# Altium schematic sheet Main.SchDoc
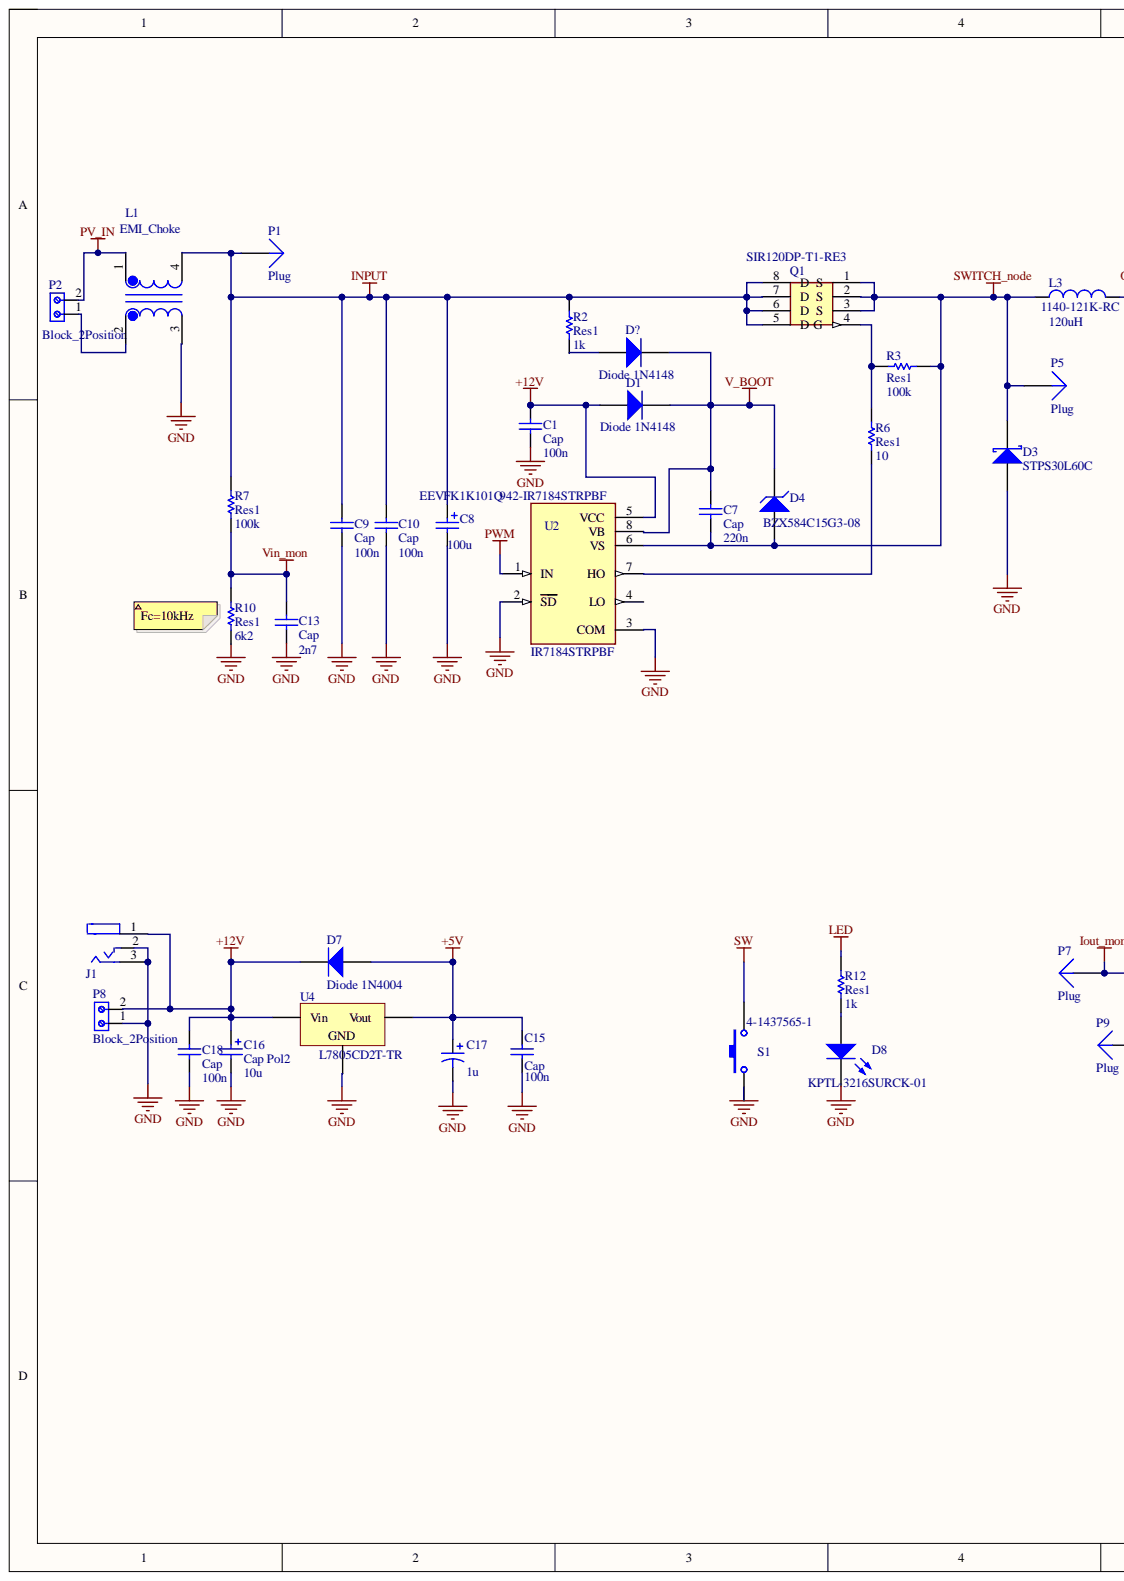
[diagram p0: Main.SchDoc, left]
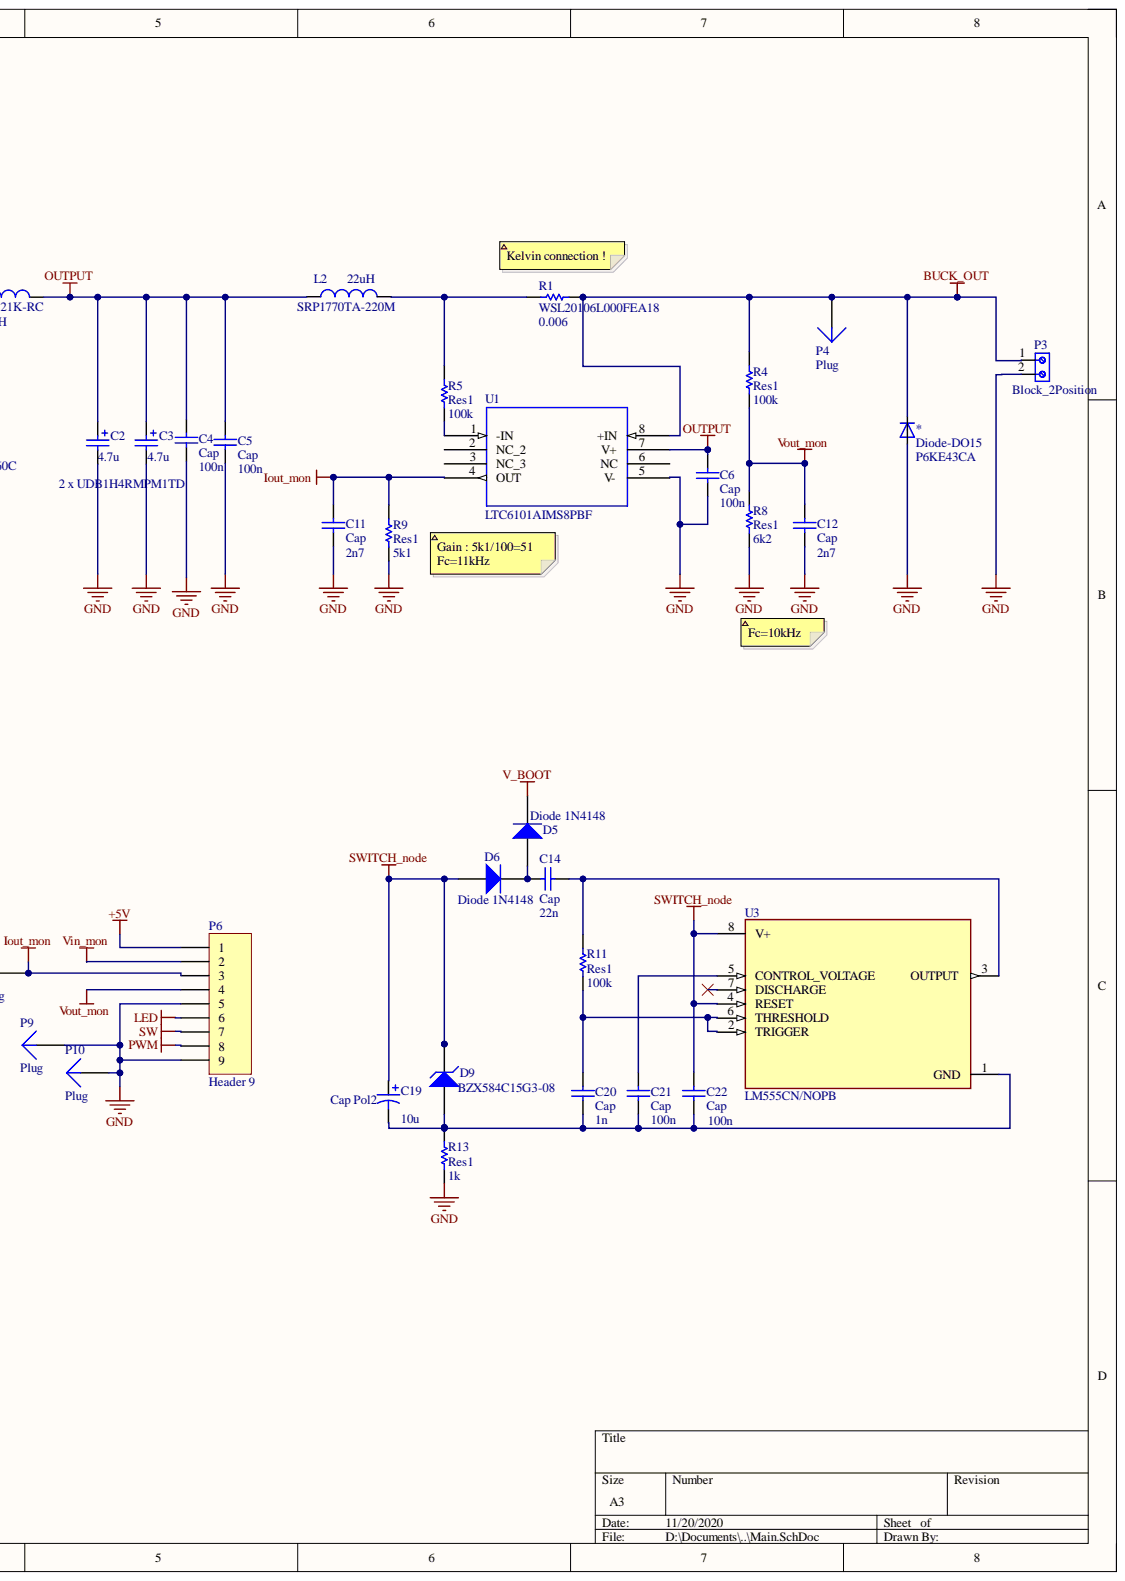
[diagram p1: Main.SchDoc, right]

## Parts
*  Diode-DO15  [Diode-DO15]
C1  Cap  value 100n  [C0805]
C2  2 x UDB1H4RMPM1TD  value 4.7u  [C1-C2]
C3  2 x UDB1H4RMPM1TD  value 4.7u  [C1-C2]
C4  Cap  value 100n  [C0805]
C5  Cap  value 100n  [C0805]
C6  Cap  value 100n  [C0805]
C7  Cap  value 220n  [C0805]
C8  EEVFK1K101Q  value 100u  [C_Pol_EEV-FK1K101Q]
C9  Cap  value 100n  [C0805]
C10  Cap  value 100n  [C0805]
C11  Cap  value 2n7  [C0805]
C12  Cap  value 2n7  [C0805]
C13  Cap  value 2n7  [C0805]
C14  Cap  value 22n  [C0805]
C15  Cap  value 100n  [C0805]
C16  Cap Pol2  value 10u  [C_ECA2CHG100]
C17  1u  [C0805]
C18  Cap  value 100n  [C0805]
C19  Cap Pol2  value 10u  [C_ECA2CHG100]
C20  Cap  value 1n  [C0805]
C21  Cap  value 100n  [C0805]
C22  Cap  value 100n  [C0805]
D1  Diode 1N4148  [diode_1N4004]
D3  STPS30L60C  [Diode_Scho]
D4  BZX584C15G3-08  [diode_zener]
D5  Diode 1N4148  [diode_1N4004]
D6  Diode 1N4148  [diode_1N4004]
D7  Diode 1N4004  [diode_1N4004]
D8  KPTL-3216SURCK-01  [LED]
D9  BZX584C15G3-08  [diode_zener]
D?  Diode 1N4148  [diode_1N4004]
J1  PJ-006A  [CUI_Inc_PJ-006A_MFG]  (value not drawn)
L1  EMI_Choke  [EMI_Choke]
L2  SRP1770TA-220M  value 22uH  [SRP1770TA-220M]
L3  1140-121K-RC  value 120uH  [L_inductor]
P1  Plug  [PIN1]
P2  Block_2Position  [Block_2Position]
P3  Block_2Position  [Block_2Position]
P4  Plug  [PIN1]
P5  Plug  [PIN1]
P6  Header 9  [HDR1X9]
P7  Plug  [PIN1]
P8  Block_2Position  [Block_2Position]
P9  Plug  [PIN1]
P10  Plug  [PIN1]
Q1  SIR120DP-T1-RE3  [MOFET_SIR120DP-T1-RE3]
R1  WSL20106L000FEA18  value 0.006  [R0.006]
R2  Res1  value 1k  [R0805]
R3  Res1  value 100k  [R0805]
R4  Res1  value 100k  [R0805]
R5  Res1  value 100k  [R0805]
R6  Res1  value 10  [R0805]
R7  Res1  value 100k  [R0805]
R8  Res1  value 6k2  [R0805]
R9  Res1  value 5k1  [R0805]
R10  Res1  value 6k2  [R0805]
R11  Res1  value 100k  [R0805]
R12  Res1  value 1k  [R0805]
R13  Res1  value 1k  [R0805]
S1  4-1437565-1  [Switch]
U1  LTC6101AIMS8PBF  [LTC6101AIMS8#PBF]
U2  IR7184STRPBF  Supplier Part Number 1: 942-IR7184STRPBF  [IR7184STRPBF]
U3  LM555CN/NOPB  [LM555CN/NOPB]
U4  L7805CD2T-TR  [Volt_Reg]

## Nets
+5V: C15.2 C17.1 D7.1 P6.1 U4.3
+12V: C1.2 C16.1 C18.2 D1.1 D7.2 P8.2 U2.5(VCC) U4.1
BUCK_OUT: *.C P3.1 P4.1 R1.1 R4.2 U1.8(+IN)
GND: *.A C1.1 C2.2 C3.2 C4.1 C5.1 C6.1 C8.2 C9.1 C10.1 C11.1 C12.1 C13.1 C15.1 C16.2 C17.2 C18.1 D3.1 D8.2 J1.2 J1.3 L1.3 P3.2 P6.5 P6.9 P8.1 P9.1 P10.1 R8.1 R9.1 R10.1 R13.2 S1.1 U1.5(V-) U2.2(SD) U2.3(COM) U4.2
INPUT: C8.1 C9.2 C10.2 L1.4 P1.1 Q1.5(D) Q1.6(D) Q1.7(D) Q1.8(D) R2.1 R7.2
Iout_mon: C11.2 P6.3 P7.1 R9.2 U1.4(OUT)
LED: P6.6 R12.1
OUTPUT: C2.1 C3.1 C4.2 C5.2 C6.2 L2.1 L3.2 U1.7(V+)
PV_IN: L1.1 P2.2
PWM: P6.8 U2.1(IN)
SW: P6.7 S1.2
SWITCH_node: C7.1 C19.1 C22.2 D3.2 D4.1 D6.1 D9.2 L3.1 P5.1 Q1.1(S) Q1.2(S) Q1.3(S) R3.1 U2.6(VS) U3.4(RESET) U3.8(V+)
V_BOOT: C7.2 D1.2 D4.2 D5.2 D?.2 U2.8(VB)
Vin_mon: C13.2 P6.2 R7.1 R10.2
Vout_mon: C12.2 P6.4 R4.1 R8.2
NetC14_1: C14.1 R11.2 U3.3(OUTPUT)
NetC14_2: C14.2 D5.1 D6.2
NetC19_2: C19.2 C20.1 C21.1 C22.1 D9.1 R13.1 U3.1(GND)
NetC20_2: C20.2 R11.1 U3.2(TRIGGER) U3.6(THRESHOLD)
NetC21_2: C21.2 U3.5(CONTROL_VOLTAGE)
NetD8_1: D8.1 R12.2
NetD?_1: D?.1 R2.2
NetL1_2: L1.2 P2.1
NetL2_2: L2.2 R1.2 R5.2
NetQ1_4: Q1.4(G) R3.2 R6.2
NetR5_1: R5.1 U1.1(-IN)
NetR6_1: R6.1 U2.7(HO)

Not connected: J1 1; U1 2(NC_2) 3(NC_3) 6(NC); U2 4(LO); U3 7(DISCHARGE)

## Library data of the parts
* = Diode-DO15: P6KE43CA; Tension: 43V; Component Kind: Standard; Library Name: Buck.SCHLIB; Ref: P6KE43CA
C1 C4-C6 C9 C10 C14 C15 C18 C21 C22 = Cap: Capacitor; Component Kind: Standard; LatestRevisionDate: 17-Jul-2002; LatestRevisionNote: Re-released for DXP Platform.; Library Name: Buck.SCHLIB; PackageDescription: Radial Cap, Thru-Hole; 2 Leads; 0.3 in Pin Spacing; PackageReference: RAD-0.3; Published: 8-Jun-2000; Simulation: CAP; Supplier 1: Mouser; Publisher: Altium Limited
C2 C3 = Cap Pol2: Component Kind: Standard; LatestRevisionDate: 17-Jul-2002; LatestRevisionNote: Re-released for DXP Platform.; Library Name: Buck.SCHLIB; PackageDescription: Polarized Capacitor; 2 Leads; PackageReference: POLAR0.8; Published: 8-Jun-2000; Simulation: CAP; Supplier 1: Digi-Key; Publisher: Altium Limited
C7 = Cap_2: Capacitor; Component Kind: Standard; LatestRevisionDate: 17-Jul-2002; LatestRevisionNote: Re-released for DXP Platform.; Library Name: Buck.SCHLIB; PackageDescription: Radial Cap, Thru-Hole; 2 Leads; 0.3 in Pin Spacing; PackageReference: RAD-0.3; Published: 8-Jun-2000; Simulation: CAP; Supplier 1: Mouser; Publisher: Altium Limited
C8 = Cap Pol2_1: Polarized Capacitor (Axial); Component Kind: Standard; LatestRevisionDate: 17-Jul-2002; LatestRevisionNote: Re-released for DXP Platform.; Library Name: Buck.SCHLIB; PackageDescription: Polarized Capacitor; 2 Leads; PackageReference: POLAR0.8; Published: 8-Jun-2000; Simulation: CAP; Supplier 1: Mouser; Publisher: Altium Limited
C11-C13 = Cap_1: Capacitor; Component Kind: Standard; LatestRevisionDate: 17-Jul-2002; LatestRevisionNote: Re-released for DXP Platform.; Library Name: Buck.SCHLIB; PackageDescription: Radial Cap, Thru-Hole; 2 Leads; 0.3 in Pin Spacing; PackageReference: RAD-0.3; Published: 8-Jun-2000; Simulation: CAP; Supplier 1: Mouser; Publisher: Altium Limited
C16 C19 = Cap Pol2_2: Polarized Capacitor (Axial); Component Kind: Standard; LatestRevisionDate: 17-Jul-2002; LatestRevisionNote: Re-released for DXP Platform.; Library Name: Buck.SCHLIB; PackageDescription: Polarized Capacitor; 2 Leads; PackageReference: POLAR0.8; Published: 8-Jun-2000; Simulation: CAP; Supplier 1: Mouser; Publisher: Altium Limited
C17 = C_4: Component Kind: Standard; Library Name: Buck.SCHLIB; Valeur: 1u
C20 = Cap_3: Capacitor; Component Kind: Standard; LatestRevisionDate: 17-Jul-2002; LatestRevisionNote: Re-released for DXP Platform.; Library Name: Buck.SCHLIB; PackageDescription: Radial Cap, Thru-Hole; 2 Leads; 0.3 in Pin Spacing; PackageReference: RAD-0.3; Published: 8-Jun-2000; Simulation: CAP; Supplier 1: Mouser; Publisher: Altium Limited
D1 D5-D7 D? = Diode 1N4004: 1 Amp General Purpose Rectifier; Code_JEDEC: DO-204-AL; Component Kind: Standard; ComponentLink1Description: Manufacturer Link; ComponentLink1URL: http://www.fairchildsemi.com/; ComponentLink2Description: Datasheet; ComponentLink2URL: http://www.fairchildsemi.com/ds/1N%2F1N4001.pdf; DatasheetVersion: 1998; LatestRevisionDate: 17-Jul-2002; LatestRevisionNote: Re-released for DXP Platform.; Library Name: Buck.SCHLIB; PackageDescription: Diode, Axial; Body 5.2 x 2.7 mm (LxDia. max), Lead Dia. 0.86 mm (max); PackageReference: DO-41; PackageVersion: Aug-1999; Published: 8-Jun-2000; Simulation: 1N4004; Supplier 1: Digi-Key; Publisher: Altium Limited
D3 = D Schottky: Schottky Diode; Code_JEDEC: DO-214-AA; Component Kind: Standard; LatestRevisionDate: 17-Jul-2002; LatestRevisionNote: Re-released for DXP Platform.; Library Name: Buck.SCHLIB; PackageDescription: DO-214-AA/SMB; 2 C-Bend Leads; Body 5.3 x 3.6 mm, inc. leads (LxW); PackageReference: SMB; Published: 8-Jun-2000; Simulation: SKYDIODE; Publisher: Altium Limited
D4 D9 = D Zener: Zener Diode 15V; Component Kind: Standard; LatestRevisionDate: 17-Jul-2002; LatestRevisionNote: Re-released for DXP Platform.; Library Name: Buck.SCHLIB; PackageDescription: Diode, Thru-Hole; 2 Leads; 0.7 in Pin Spacing; PackageReference: DIODE-0.7; Published: 8-Jun-2000; Simulation: ZENER; Supplier 1: Mouser; Publisher: Altium Limited
D8 = LED2: Typical RED, GREEN, YELLOW, AMBER GaAs LED; Component Kind: Standard; LatestRevisionDate: 17-Jul-2002; LatestRevisionNote: Re-released for DXP Platform.; Library Name: Buck.SCHLIB; PackageDescription: SM LED; 2 Flat Leads; PackageReference: 3.2X1.6X1.1; PackageVersion: Feb-2002; Published: 8-Jun-2000; Simulation: LED2; Supplier 1: Farnell; Publisher: Altium Limited
J1 = PJ-006A: 2.1mm DC Power Jack; Component Kind: Standard; Library Name: Buck.SCHLIB
L1 = EMI_Choke: 1mH 20A; Component Kind: Standard; Library Name: Buck.SCHLIB
L3 = Inductor: Code_IEC: 1005; Code_JEITA: 0402; Component Kind: Standard; LatestRevisionDate: 29-May-2009; LatestRevisionNote: IPC-7351 Footprint Added.; Library Name: Buck.SCHLIB; PackageDescription: Chip Inductor; PackageReference: 0402-A; Published: 8-Jun-2000; Simulation: INDUCTOR; Supplier 1: Mouser; Publisher: Altium Limited
P6 = Header 9: Header, 9-Pin; Component Kind: Standard; LatestRevisionDate: 17-Jul-2002; LatestRevisionNote: Re-released for DXP Platform.; Library Name: Miscellaneous Connectors.IntLib; Publisher: Altium Limited
R1 = Res1_2: Resistor; Component Kind: Standard; LatestRevisionDate: 17-Jul-2002; LatestRevisionNote: Re-released for DXP Platform.; Library Name: Buck.SCHLIB; PackageDescription: Axial Device, Thru-Hole; 2 Leads; 0.3 in Pin Spacing; PackageReference: AXIAL-0.3; Published: 8-Jun-2000; Simulation: RESISTOR; Supplier 1: Mouser; Publisher: Altium Limited
R2 R12 R13 = Res1_6: Resistor; Component Kind: Standard; LatestRevisionDate: 17-Jul-2002; LatestRevisionNote: Re-released for DXP Platform.; Library Name: Buck.SCHLIB; PackageDescription: Axial Device, Thru-Hole; 2 Leads; 0.3 in Pin Spacing; PackageReference: AXIAL-0.3; Published: 8-Jun-2000; Simulation: RESISTOR; Supplier 1: Mouser; Publisher: Altium Limited
R3-R5 R7 R11 = Res1: Resistor; Component Kind: Standard; LatestRevisionDate: 17-Jul-2002; LatestRevisionNote: Re-released for DXP Platform.; Library Name: Buck.SCHLIB; PackageDescription: Axial Device, Thru-Hole; 2 Leads; 0.3 in Pin Spacing; PackageReference: AXIAL-0.3; Published: 8-Jun-2000; Simulation: RESISTOR; Supplier 1: Digi-Key; Supplier 2: Mouser; Publisher: Altium Limited
R6 = Res1_5: Resistor; Component Kind: Standard; LatestRevisionDate: 17-Jul-2002; LatestRevisionNote: Re-released for DXP Platform.; Library Name: Buck.SCHLIB; PackageDescription: Axial Device, Thru-Hole; 2 Leads; 0.3 in Pin Spacing; PackageReference: AXIAL-0.3; Published: 8-Jun-2000; Simulation: RESISTOR; Supplier 1: Mouser; Publisher: Altium Limited
R8 R10 = Res1_1: Resistor; Component Kind: Standard; LatestRevisionDate: 17-Jul-2002; LatestRevisionNote: Re-released for DXP Platform.; Library Name: Buck.SCHLIB; PackageDescription: Axial Device, Thru-Hole; 2 Leads; 0.3 in Pin Spacing; PackageReference: AXIAL-0.3; Published: 8-Jun-2000; Simulation: RESISTOR; Supplier 1: Mouser; Publisher: Altium Limited
R9 = Res1_4: Resistor; Component Kind: Standard; LatestRevisionDate: 17-Jul-2002; LatestRevisionNote: Re-released for DXP Platform.; Library Name: Buck.SCHLIB; PackageDescription: Axial Device, Thru-Hole; 2 Leads; 0.3 in Pin Spacing; PackageReference: AXIAL-0.3; Published: 8-Jun-2000; Simulation: RESISTOR; Supplier 1: Mouser; Publisher: Altium Limited
S1 = SW-PB: Switch; Component Kind: Standard; LatestRevisionDate: 17-Jul-2002; LatestRevisionNote: Re-released for DXP Platform.; Library Name: Buck.SCHLIB; PackageDescription: Switch; 2 Leads; PackageReference: SPST-2; Published: 24-Mar-1999; Supplier 1: Mouser; Publisher: Altium Limited
U1 = LTC6101AIMS8PBF: SP Amp Current Sense Amp Single 60V Automotive 8-Pin MSOP Tube; MF: Analog Devices; Availability: Warning; Check_prices: https://www.snapeda.com/parts/LTC6101AIMS8%23PBF/Analog%20Devices/view-part/4331140/?ref=eda; Component Kind: Standard; Library Name: Buck.SCHLIB; MP: LTC6101AIMS8#PBF; Package: MSOP-8 Analog Devices; Price: None; Purchase-URL: https://pricing.snapeda.com/search/part/LTC6101AIMS8#PBF/?ref=eda; SnapEDA_Link: https://www.snapeda.com/parts/LTC6101AIMS8%23PBF/Analog%20Devices/view-part/4331140/?ref=snap; Supplier 1: Mouser
U2 = IR7184STRPBF: Drives IGBT/MOSFET power devices | Gate drive supplies up to 20 V per channel | Integrated deadtime protection | Shoot-through _cross-conduction_ protection | Undervoltage lockout for VCC for VBS | 3.3 V, 5 V , 15 V input logic compatible | Tolerant to negative transient voltage | Designed for use with bootstrap power supplies | Matched propagation delays | -40C to 125C operating range; MF: Infineon Technologies; Availability: Unavailable; Component Kind: Standard; Library Name: Buck.SCHLIB; MP: IR7184STRPBF; Package: SOIC-8 Infineon; Price: None; SnapEDA_Link: https://www.snapeda.com/parts/IR7184STRPBF/Infineon%20Technologies/view-part/1224754/?ref=snap; Supplier 1: Mouser
U4 = Volt Reg: Voltage Regulator; Component Kind: Standard; LatestRevisionDate: 29-May-2009; LatestRevisionNote: IPC-7351 Footprint Added.; Library Name: Buck.SCHLIB; PackageDescription: 3-Pin Transistor Outline Package 2.54 mm Pitch, 15.1 mm Lead Span; PackageReference: D2PAK; Published: 24-Mar-1999; Supplier 1: Mouser; Publisher: Altium Limited
L2 = SRP1770TA-220M: Component Kind: Standard; Library Name: Buck.SCHLIB
P1 P4 P5 P7 P9 P10 = Plug: Component Kind: Standard; Description: Plug; LatestRevisionDate: 17-Jul-2002; LatestRevisionNote: Re-released for DXP Platform.; Library Name: Miscellaneous Connectors.IntLib; Publisher: Altium Limited
P2 P3 P8 = Block_2Position: Component Kind: Standard; Library Name: Buck.SCHLIB
Q1 = SIR120DP-T1-RE3: Component Kind: Standard; Library Name: Buck.SCHLIB
U3 = LM555CN/NOPB: Availability: Unavailable; Component Kind: Standard; Library Name: Buck.SCHLIB; MP: LM555CN/NOPB; Package: None; Price: None; Supplier 1: Mouser
